# Altium schematic sheet S7CAS-PLEIADES-CARTE-FILLE_P02_ADC.SchDoc
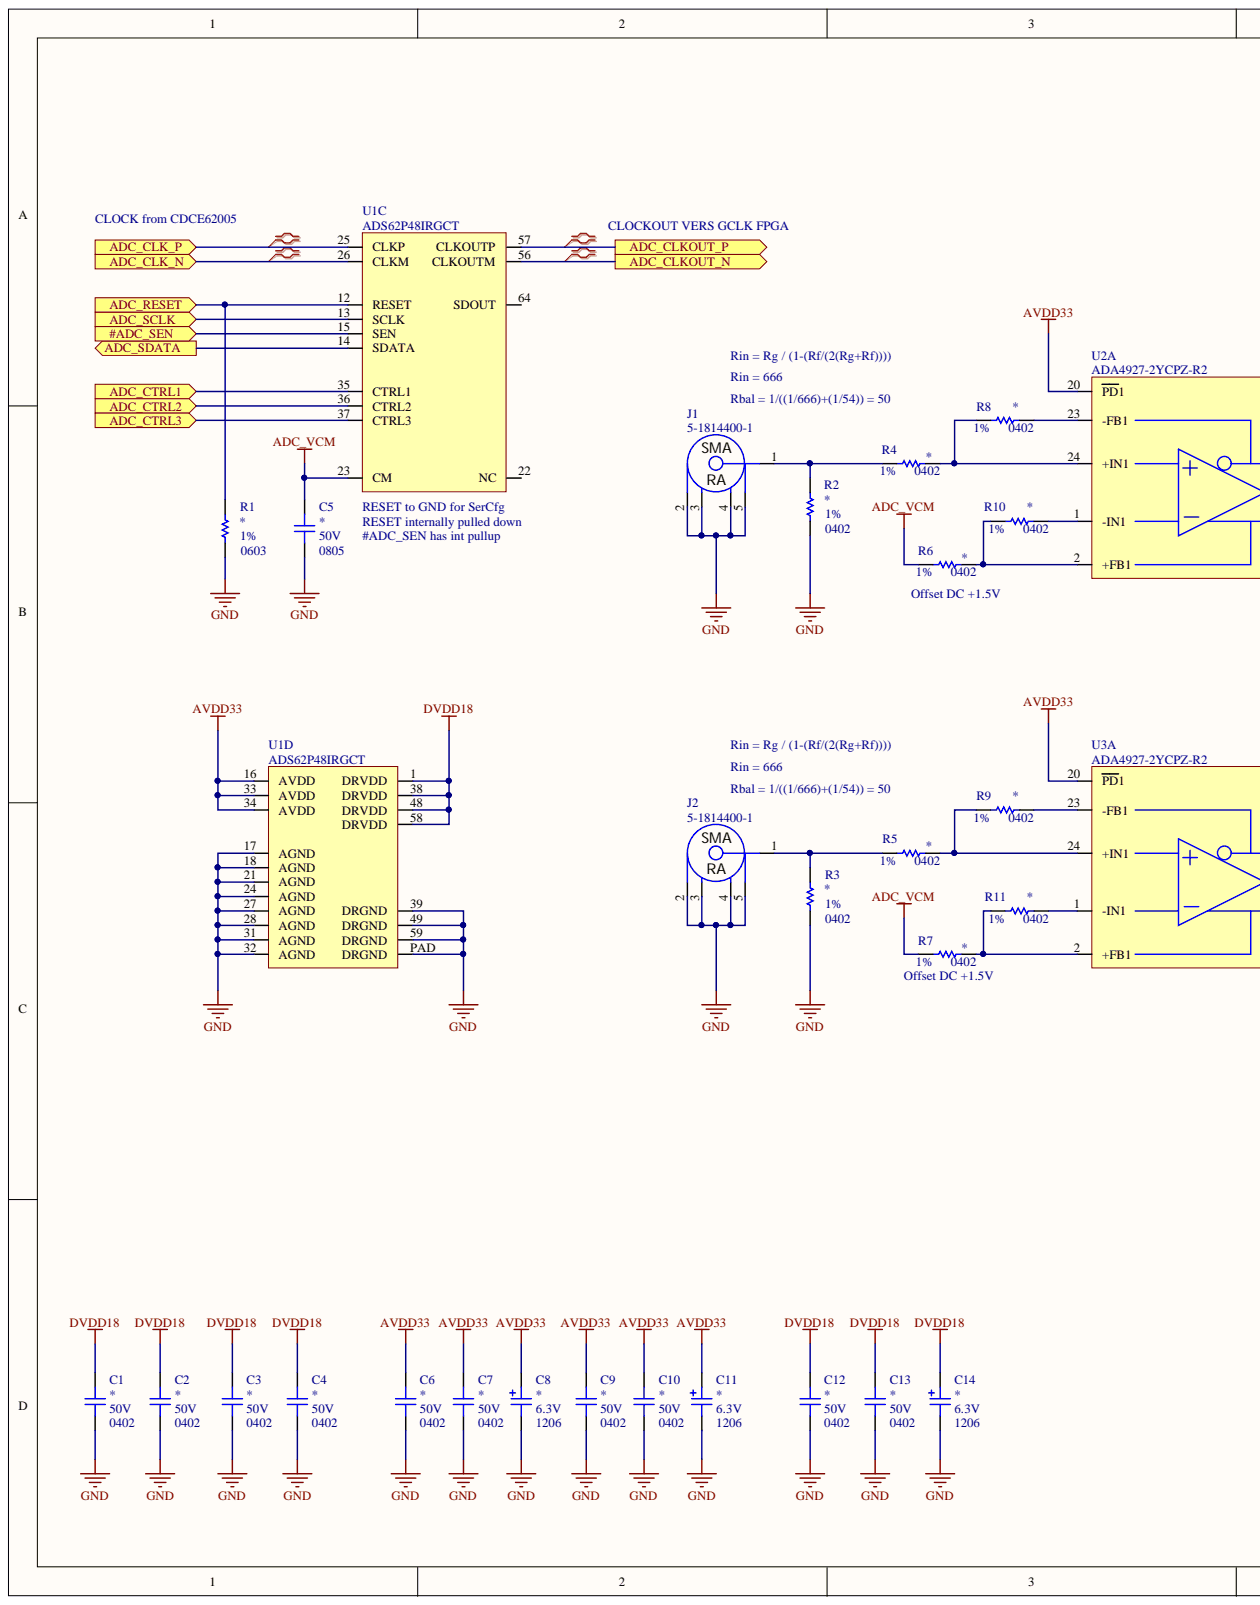
[diagram p0: S7CAS-PLEIADES-CARTE-FILLE_P02_ADC.SchDoc, left]
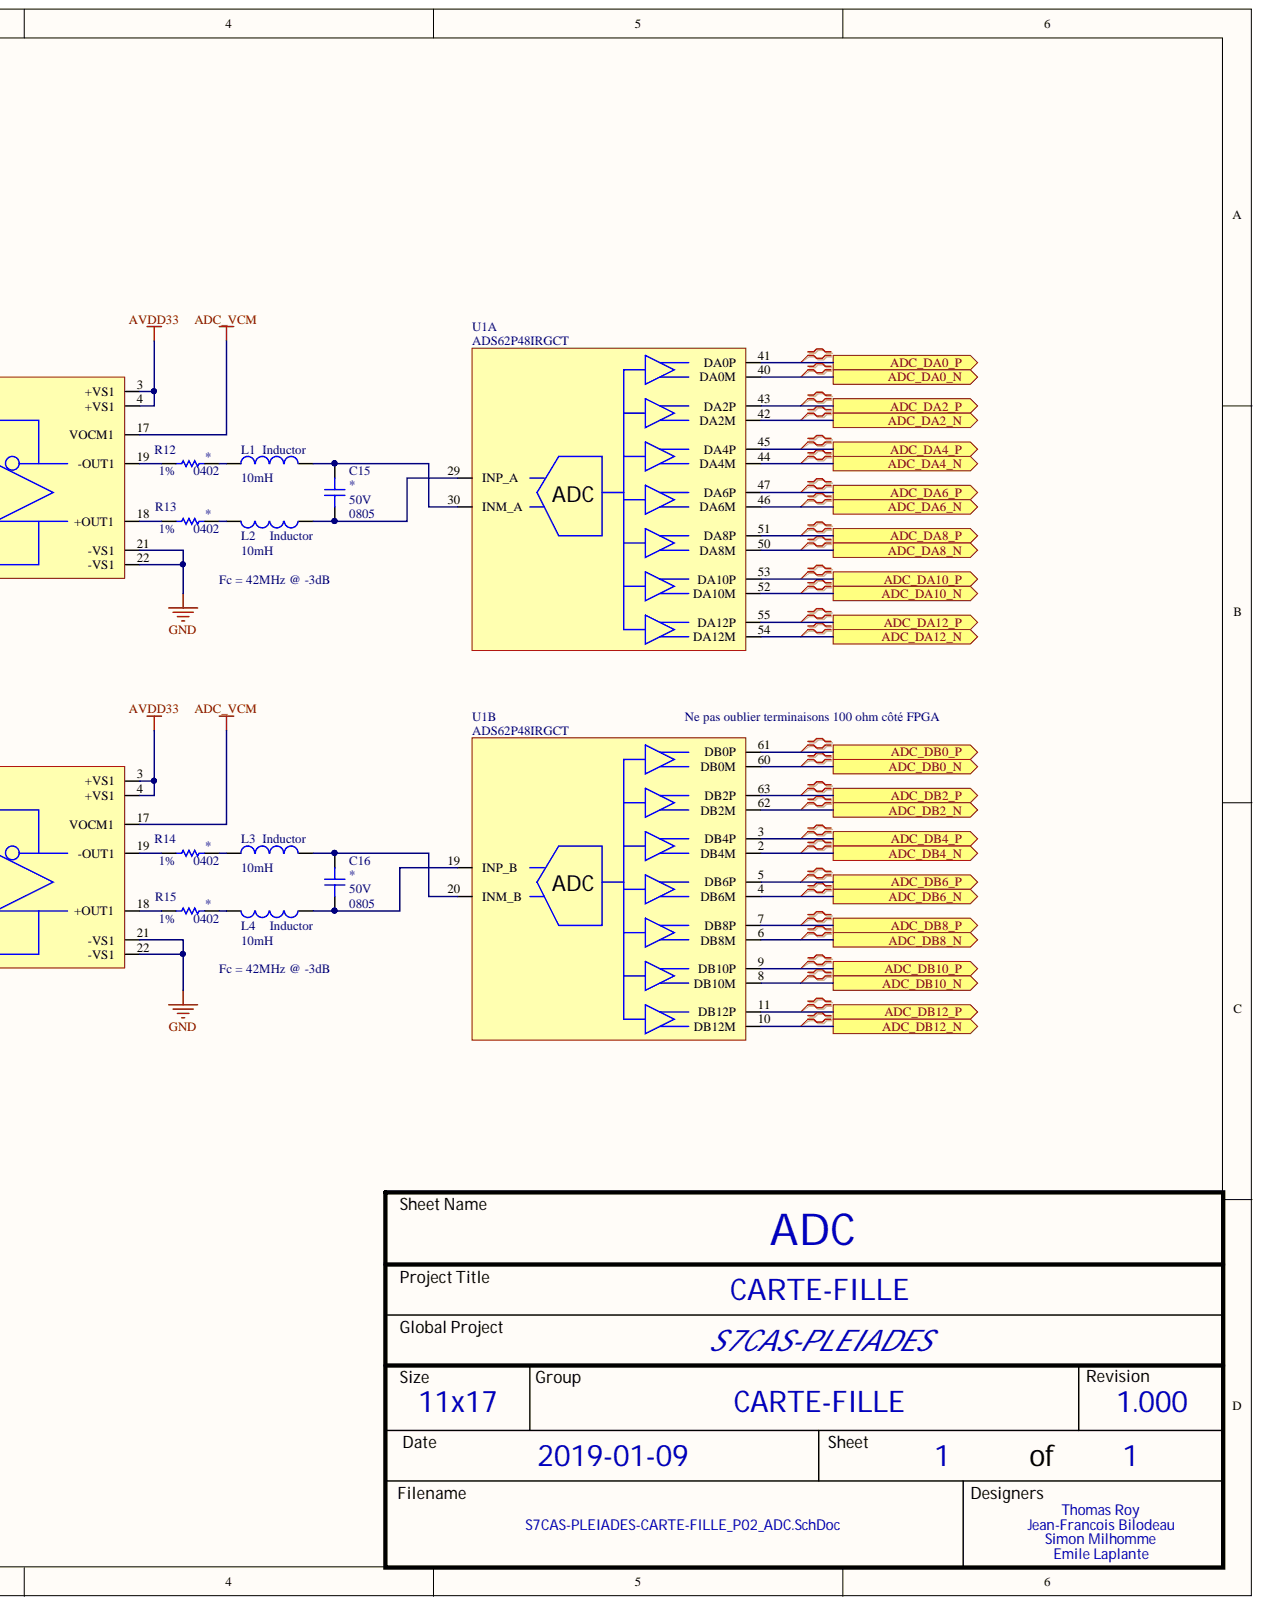
[diagram p1: S7CAS-PLEIADES-CARTE-FILLE_P02_ADC.SchDoc, right]

## Parts
C1  Package: 0402  Voltage: 50V  [CAP_0402]  (hidden comment: CAP - 0402)
C2  Package: 0402  Voltage: 50V  [CAP_0402]  (hidden comment: CAP - 0402)
C3  Package: 0402  Voltage: 50V  [CAP_0402]  (hidden comment: CAP - 0402)
C4  Package: 0402  Voltage: 50V  [CAP_0402]  (hidden comment: CAP - 0402)
C5  Package: 0805  Voltage: 50V  [CAP_0805]  (hidden comment: CAP - 0805)
C6  Package: 0402  Voltage: 50V  [CAP_0402]  (hidden comment: CAP - 0402)
C7  Package: 0402  Voltage: 50V  [CAP_0402]  (hidden comment: CAP - 0402)
C8  Package: 1206  Voltage: 6.3V  [CAP_TAN_1206(A)]  (hidden comment: CAP - TANTALUM - CASE A)
C9  Package: 0402  Voltage: 50V  [CAP_0402]  (hidden comment: CAP - 0402)
C10  Package: 0402  Voltage: 50V  [CAP_0402]  (hidden comment: CAP - 0402)
C11  Package: 1206  Voltage: 6.3V  [CAP_TAN_1206(A)]  (hidden comment: CAP - TANTALUM - CASE A)
C12  Package: 0402  Voltage: 50V  [CAP_0402]  (hidden comment: CAP - 0402)
C13  Package: 0402  Voltage: 50V  [CAP_0402]  (hidden comment: CAP - 0402)
C14  Package: 1206  Voltage: 6.3V  [CAP_TAN_1206(A)]  (hidden comment: CAP - TANTALUM - CASE A)
C15  Package: 0805  Voltage: 50V  [CAP_0805]  (hidden comment: CAP - 0805)
C16  Package: 0805  Voltage: 50V  [CAP_0805]  (hidden comment: CAP - 0805)
J1  5-1814400-1  [CONN_SMA_5-1814400-1]
J2  5-1814400-1  [CONN_SMA_5-1814400-1]
L1  Inductor  value 10mH  Code_JEITA: 0402  [0402-A]
L2  Inductor  value 10mH  Code_JEITA: 0402  [0402-A]
L3  Inductor  value 10mH  Code_JEITA: 0402  [0402-A]
L4  Inductor  value 10mH  Code_JEITA: 0402  [0402-A]
R1  Package: 0603  Precision: 1%  [RES_0603]  (hidden comment: RES - 0603)
R2  Package: 0402  Precision: 1%  [RES_0402]  (hidden comment: RES - 0402)
R3  Package: 0402  Precision: 1%  [RES_0402]  (hidden comment: RES - 0402)
R4  Package: 0402  Precision: 1%  [RES_0402]  (hidden comment: RES - 0402)
R5  Package: 0402  Precision: 1%  [RES_0402]  (hidden comment: RES - 0402)
R6  Package: 0402  Precision: 1%  [RES_0402]  (hidden comment: RES - 0402)
R7  Package: 0402  Precision: 1%  [RES_0402]  (hidden comment: RES - 0402)
R8  Package: 0402  Precision: 1%  [RES_0402]  (hidden comment: RES - 0402)
R9  Package: 0402  Precision: 1%  [RES_0402]  (hidden comment: RES - 0402)
R10  Package: 0402  Precision: 1%  [RES_0402]  (hidden comment: RES - 0402)
R11  Package: 0402  Precision: 1%  [RES_0402]  (hidden comment: RES - 0402)
R12  Package: 0402  Precision: 1%  [RES_0402]  (hidden comment: RES - 0402)
R13  Package: 0402  Precision: 1%  [RES_0402]  (hidden comment: RES - 0402)
R14  Package: 0402  Precision: 1%  [RES_0402]  (hidden comment: RES - 0402)
R15  Package: 0402  Precision: 1%  [RES_0402]  (hidden comment: RES - 0402)
U1  ADS62P48IRGCT  [ADC_ADS62P48IRGCT]  (parts A,B,C,D)
U2  ADA4927-2YCPZ-R2  [OPAMP_ADA4927-2YCPZ-R2]  (part A)
U3  ADA4927-2YCPZ-R2  [OPAMP_ADA4927-2YCPZ-R2]  (part A)

## Ports
#ADC_SEN, ADC_CLK_N, ADC_CLK_P, ADC_CLKOUT_N, ADC_CLKOUT_P, ADC_CTRL1, ADC_CTRL2, ADC_CTRL3, ADC_DA0_N, ADC_DA0_P, ADC_DA2_N, ADC_DA2_P, ADC_DA4_N, ADC_DA4_P, ADC_DA6_N, ADC_DA6_P, ADC_DA8_N, ADC_DA8_P, ADC_DA10_N, ADC_DA10_P, ADC_DA12_N, ADC_DA12_P, ADC_DB0_N, ADC_DB0_P, ADC_DB2_N, ADC_DB2_P, ADC_DB4_N, ADC_DB4_P, ADC_DB6_N, ADC_DB6_P, ADC_DB8_N, ADC_DB8_P, ADC_DB10_N, ADC_DB10_P, ADC_DB12_N, ADC_DB12_P, ADC_RESET, ADC_SCLK, ADC_SDATA

## Nets
ADC_VCM: C5.2 R6.2 R7.2 U1.23(CM) U2.17(VOCM1) U3.17(VOCM1)
AVDD33: C6.2 C7.2 C8.2 C9.2 C10.2 C11.2 U1.16(AVDD) U1.33(AVDD) U1.34(AVDD) U2.3(+VS1) U2.4(+VS1) U2.20(PD1) U3.3(+VS1) U3.4(+VS1) U3.20(PD1)
DVDD18: C1.2 C2.2 C3.2 C4.2 C12.2 C13.2 C14.2 U1.1(DRVDD) U1.38(DRVDD) U1.48(DRVDD) U1.58(DRVDD)
GND: C1.1 C2.1 C3.1 C4.1 C5.1 C6.1 C7.1 C8.1 C9.1 C10.1 C11.1 C12.1 C13.1 C14.1 J1.2 J1.3 J1.4 J1.5 J2.2 J2.3 J2.4 J2.5 R1.1 R2.1 R3.1 U1.17(AGND) U1.18(AGND) U1.21(AGND) U1.24(AGND) U1.27(AGND) U1.28(AGND) U1.31(AGND) U1.32(AGND) U1.39(DRGND) U1.49(DRGND) U1.59(DRGND) U1.PAD(DRGND) U2.21(-VS1) U2.22(-VS1) U3.21(-VS1) U3.22(-VS1)
#ADC_SEN: U1.15(SEN) ; port #ADC_SEN
ADC_CLK_N: U1.26(CLKM) ; port ADC_CLK_N
ADC_CLK_P: U1.25(CLKP) ; port ADC_CLK_P
ADC_CLKOUT_N: U1.56(CLKOUTM) ; port ADC_CLKOUT_N
ADC_CLKOUT_P: U1.57(CLKOUTP) ; port ADC_CLKOUT_P
ADC_CTRL1: U1.35(CTRL1) ; port ADC_CTRL1
ADC_CTRL2: U1.36(CTRL2) ; port ADC_CTRL2
ADC_CTRL3: U1.37(CTRL3) ; port ADC_CTRL3
ADC_DA0_N: U1.40(DA0M) ; port ADC_DA0_N
ADC_DA0_P: U1.41(DA0P) ; port ADC_DA0_P
ADC_DA2_N: U1.42(DA2M) ; port ADC_DA2_N
ADC_DA2_P: U1.43(DA2P) ; port ADC_DA2_P
ADC_DA4_N: U1.44(DA4M) ; port ADC_DA4_N
ADC_DA4_P: U1.45(DA4P) ; port ADC_DA4_P
ADC_DA6_N: U1.46(DA6M) ; port ADC_DA6_N
ADC_DA6_P: U1.47(DA6P) ; port ADC_DA6_P
ADC_DA8_N: U1.50(DA8M) ; port ADC_DA8_N
ADC_DA8_P: U1.51(DA8P) ; port ADC_DA8_P
ADC_DA10_N: U1.52(DA10M) ; port ADC_DA10_N
ADC_DA10_P: U1.53(DA10P) ; port ADC_DA10_P
ADC_DA12_N: U1.54(DA12M) ; port ADC_DA12_N
ADC_DA12_P: U1.55(DA12P) ; port ADC_DA12_P
ADC_DB0_N: U1.60(DB0M) ; port ADC_DB0_N
ADC_DB0_P: U1.61(DB0P) ; port ADC_DB0_P
ADC_DB2_N: U1.62(DB2M) ; port ADC_DB2_N
ADC_DB2_P: U1.63(DB2P) ; port ADC_DB2_P
ADC_DB4_N: U1.2(DB4M) ; port ADC_DB4_N
ADC_DB4_P: U1.3(DB4P) ; port ADC_DB4_P
ADC_DB6_N: U1.4(DB6M) ; port ADC_DB6_N
ADC_DB6_P: U1.5(DB6P) ; port ADC_DB6_P
ADC_DB8_N: U1.6(DB8M) ; port ADC_DB8_N
ADC_DB8_P: U1.7(DB8P) ; port ADC_DB8_P
ADC_DB10_N: U1.8(DB10M) ; port ADC_DB10_N
ADC_DB10_P: U1.9(DB10P) ; port ADC_DB10_P
ADC_DB12_N: U1.10(DB12M) ; port ADC_DB12_N
ADC_DB12_P: U1.11(DB12P) ; port ADC_DB12_P
ADC_RESET: R1.2 U1.12(RESET) ; port ADC_RESET
ADC_SCLK: U1.13(SCLK) ; port ADC_SCLK
ADC_SDATA: U1.14(SDATA) ; port ADC_SDATA
NetC15_1: C15.1 L2.1 U1.29(INP_A)
NetC15_2: C15.2 L1.2 U1.30(INM_A)
NetC16_1: C16.1 L4.1 U1.19(INP_B)
NetC16_2: C16.2 L3.2 U1.20(INM_B)
NetJ1_1: J1.1 R2.2 R4.2
NetJ2_1: J2.1 R3.2 R5.2
NetL1_1: L1.1 R12.1
NetL2_2: L2.2 R13.1
NetL3_1: L3.1 R14.1
NetL4_2: L4.2 R15.1
NetR4_1: R4.1 R8.2 U2.24(+IN1)
NetR5_1: R5.1 R9.2 U3.24(+IN1)
NetR6_1: R6.1 R10.2 U2.2(+FB1)
NetR7_1: R7.1 R11.2 U3.2(+FB1)
NetR8_1: R8.1 U2.23(-FB1)
NetR9_1: R9.1 U3.23(-FB1)
NetR10_1: R10.1 U2.1(-IN1)
NetR11_1: R11.1 U3.1(-IN1)
NetR12_2: R12.2 U2.19(-OUT1)
NetR13_2: R13.2 U2.18(+OUT1)
NetR14_2: R14.2 U3.19(-OUT1)
NetR15_2: R15.2 U3.18(+OUT1)

Not connected: U1 22(NC) 64(SDOUT)

## Library data of the parts
C1-C4 C6 C7 C9 C10 C12 C13 = CAP - 0402: Precision: 10%; Component Kind: Standard; Description: CAP - 0402; Library Name: S7CAS_Lib2019_REV1_00.IntLib; CreatedBy: Jonathan Bouchard
C5 C15 C16 = CAP - 0805: Precision: 10%; Component Kind: Standard; Description: CAP - 0805; Library Name: S7CAS_Lib2019_REV1_00.IntLib; CreatedBy: Jonathan Bouchard
C8 C11 C14 = CAP_TANT_1206(A): CAP - TANTALUM - 1206; Precision: 10%; Component Kind: Standard; Library Name: S7CAS_Lib2019_REV1_00.IntLib; CreatedBy: Jonathan Bouchard
J1 J2 = CONN_SMA_5-1814400-1: CONN -  SMA JACK R/A 50 OHM - TH; Mfg: TE Connectivity AMP Connectors; Component Kind: Standard; HelpURL: http://www.te.com/commerce/DocumentDelivery/DDEController?Action=srchrtrv&DocNm=1814400&DocType=Customer+Drawing&DocLang=English; Library Name: S7CAS_Lib2019_REV1_00.IntLib; Mfg Part Number: 5-1814400-1; Package: TH-5; Supplier 1: Digikey; Supplier Part Number 1: A97593-ND; Temperature: -55°C ~ 85°C; CreatedBy: Jonathan Bouchard
L1-L4 = Inductor: Code_IEC: 1005; Component Kind: Standard; Description: Inductor; LatestRevisionDate: 29-May-2009; LatestRevisionNote: IPC-7351 Footprint Added.; Library Name: Miscellaneous Devices.IntLib; PackageDescription: Chip Inductor; PackageReference: 0402-A; Published: 8-Jun-2000; Signal Integrity: Inductor; Simulation: INDUCTOR; Publisher: Altium Limited
R2-R15 = RES - 0402: Power: 1/16W; Voltage: 50V; Component Kind: Standard; Description: RES - 0402; Library Name: S7CAS_Lib2019_REV1_00.IntLib; CreatedBy: Jonathan Bouchard
U1 = ADC_ADS62P48IRGCT: ADC - 14 BIT 210MSPS SUAL - VQFN-64; Mfg: Texas Instruments; Precision: 14 Bit; Voltage: 3.15 V ~ 3.6 V / 1.7 V ~ 1.9 V; Component Kind: Standard; HelpURL: http://www.ti.com/lit/ds/symlink/ads62p48.pdf; Library Name: S7CAS_Lib2019_REV1_00.IntLib; Mfg Part Number: ADS62P48IRGCT; Package: VQFN-64; Supplier 1: Digikey; Supplier Part Number 1: 296-24611-1-ND; Temperature: -40°C ~ 85°C; CreatedBy: Jonathan Bouchard
U2 U3 = OPAMP_ADA4927-2YCPZ-R2: OPAMP - CFA 2.3GHZ - QFN-24; Current: 65mA; Mfg: Analog Devices; Voltage: 4.5 V ~ 11 V, ±2.25 V ~ 5.5 V; Component Kind: Standard; HelpURL: http://www.analog.com/media/en/technical-documentation/data-sheets/ADA4927-1_4927-2.pdf; Library Name: S7CAS_Lib2019_REV1_00.IntLib; Mfg Part Number: ADA4927-2YCPZ-R2; Package: QFN-24; Supplier 1: Digikey; Supplier Part Number 1: ADA4927-2YCPZ-R2CT-ND; Temperature: -40°C ~ 105°C; CreatedBy: Jonathan Bouchard
R1 = RES - 0603: Component Kind: Standard; Description: RES - 0603; Library Name: S7CAS_Lib2019_REV1_00.IntLib; CreatedBy: Jonathan Bouchard
